# Altium schematic sheet 5.04.51805A02_STMSch.SchDoc(5.04.51805A02_STMSch)
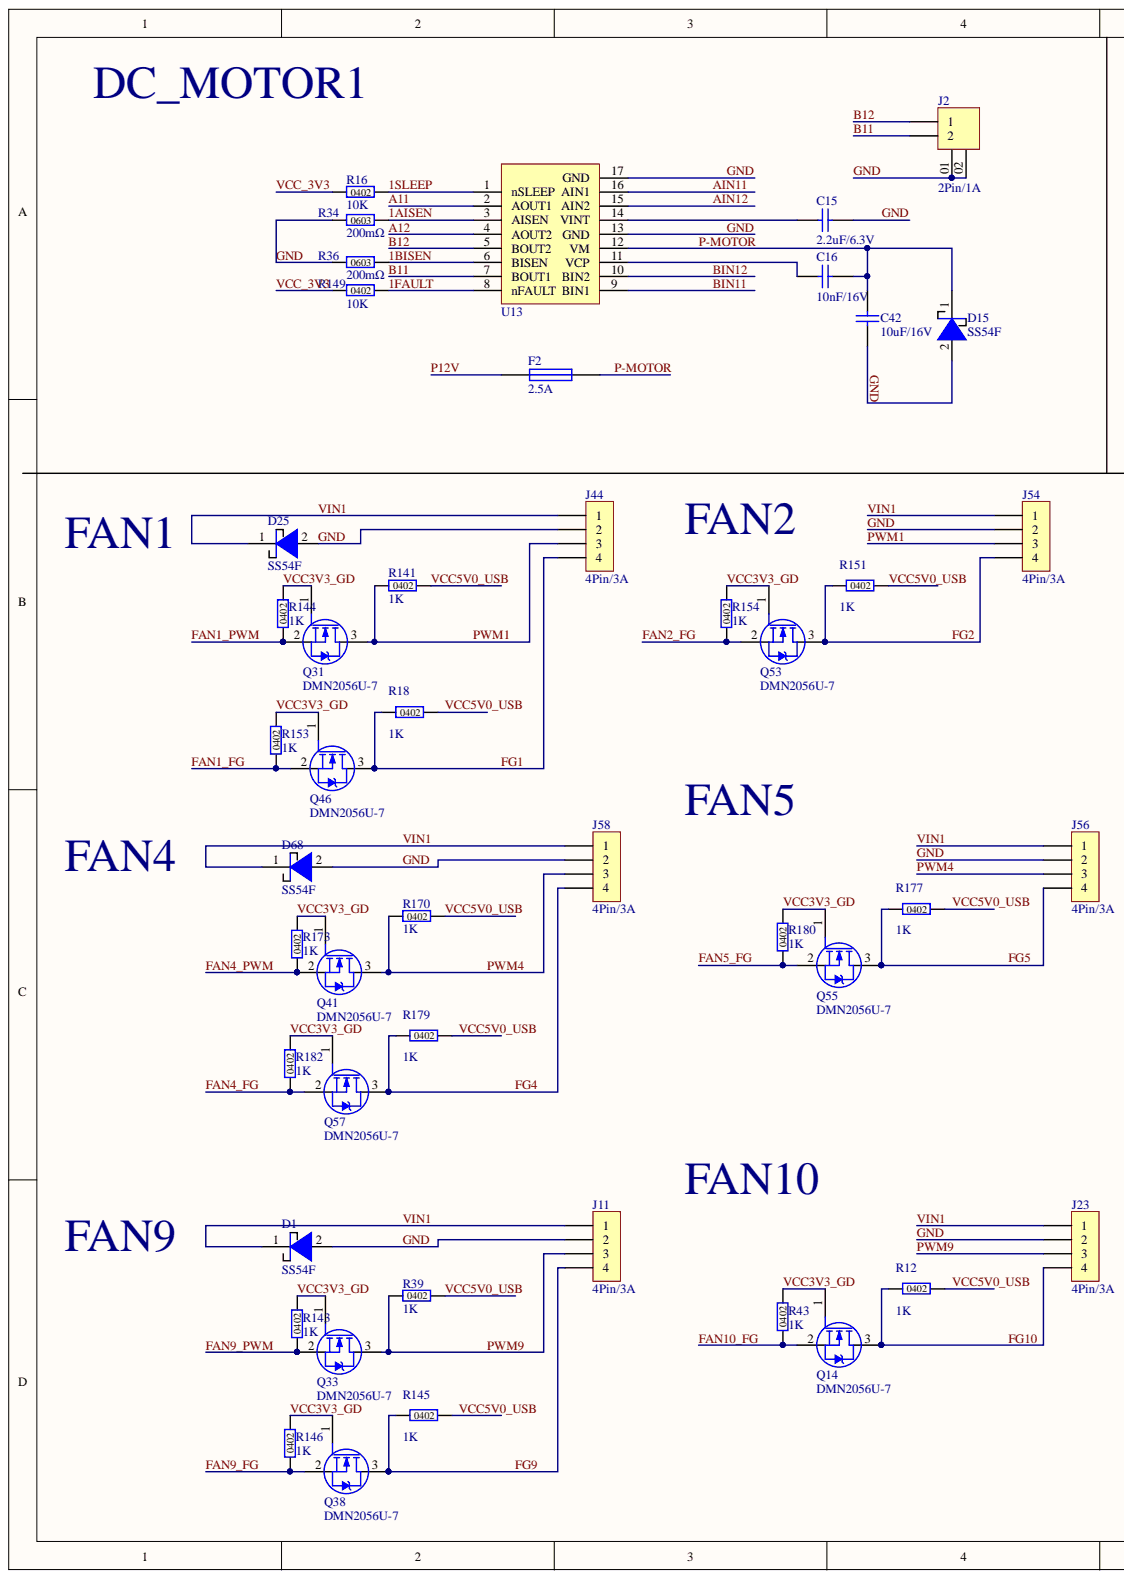
[diagram p0: 5.04.51805A02_STMSch.SchDoc, left]
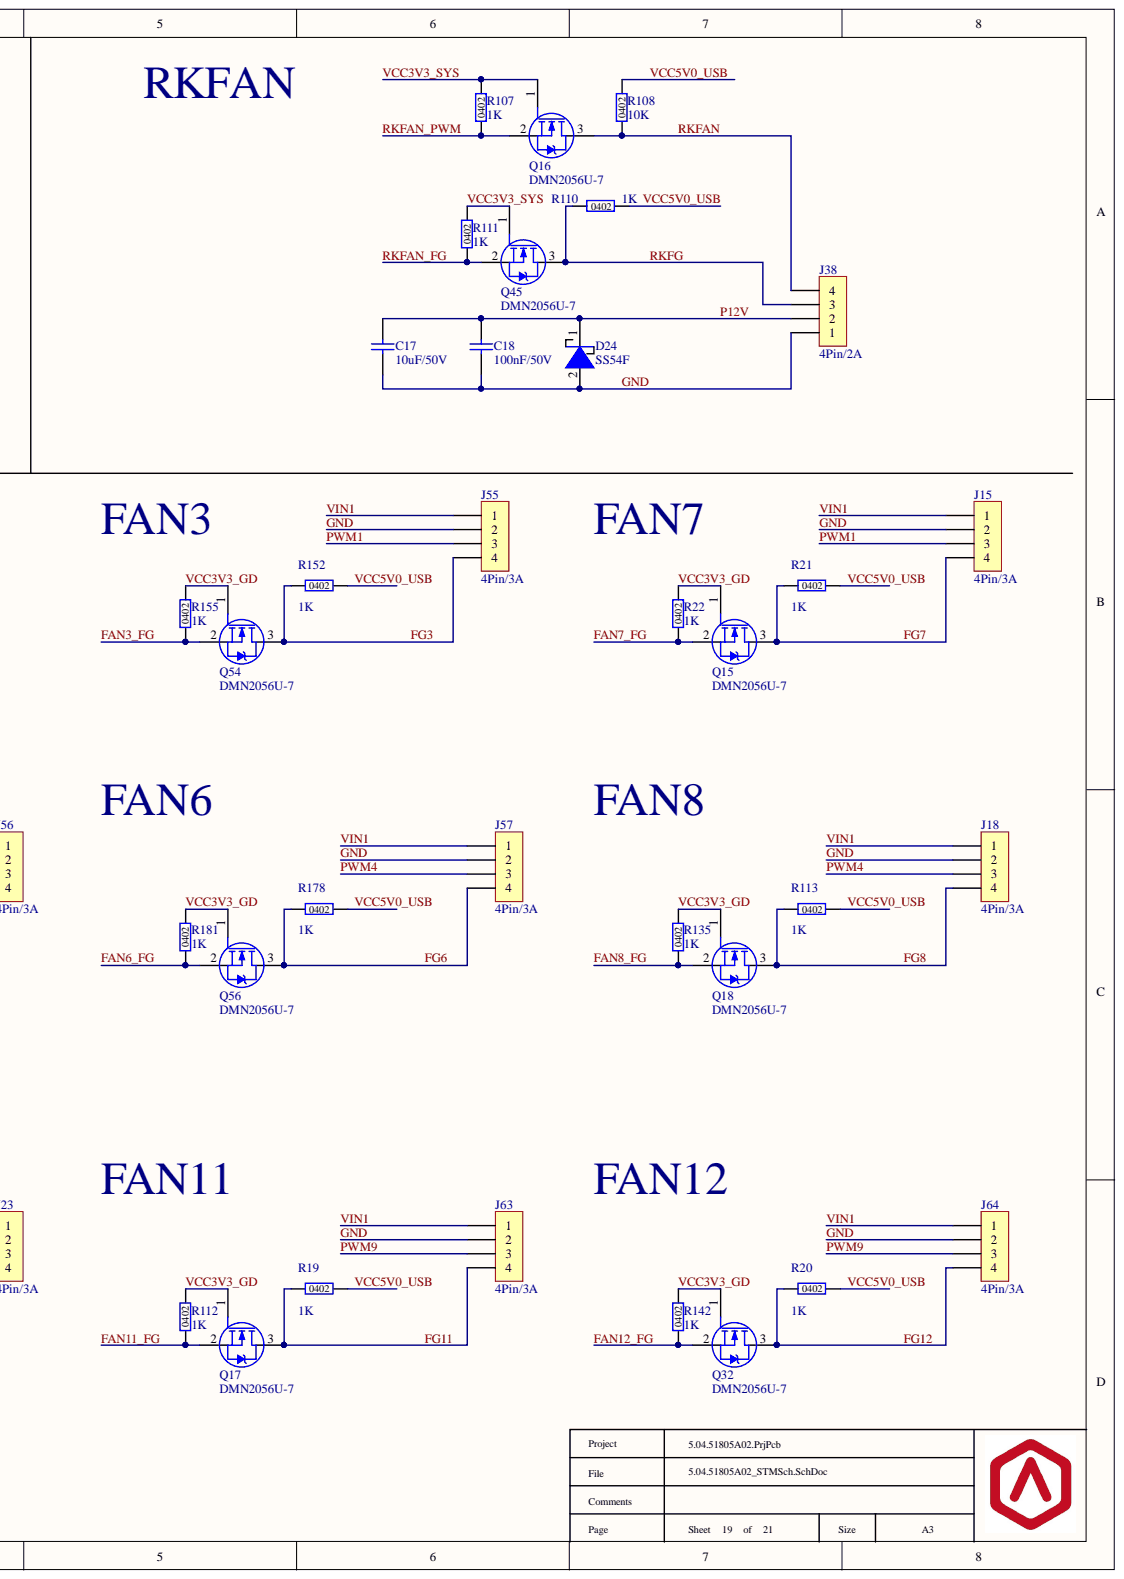
[diagram p1: 5.04.51805A02_STMSch.SchDoc, right]

## Parts
C15  2.2uF/6.3V  [C0402]
C16  10nF/16V  [C0402]
C17  10uF/50V  [C0805]
C18  100nF/50V  [C0402]
C42  10uF/16V  [C0603]
D1  SS54F  [SMAF_L3.5-W2.6-LS4.7-RD]
D15  SS54F  [SMAF_L3.5-W2.6-LS4.7-RD]
D24  SS54F  [SMAF_L3.5-W2.6-LS4.7-RD]
D25  SS54F  [SMAF_L3.5-W2.6-LS4.7-RD]
D68  SS54F  [SMAF_L3.5-W2.6-LS4.7-RD]
F2  2.5A  [F0603]
J2  2Pin/1A  [BM02B-GHS-TBT(LF)(SN)]
J11  4Pin/3A  [B04B-PASK-1(LF)(SN)]
J15  4Pin/3A  [B04B-PASK-1(LF)(SN)]
J18  4Pin/3A  [B04B-PASK-1(LF)(SN)]
J23  4Pin/3A  [B04B-PASK-1(LF)(SN)]
J38  4Pin/2A  [B4B-PH-K-S(LF)(SN)]
J44  4Pin/3A  [B04B-PASK-1(LF)(SN)]
J54  4Pin/3A  [B04B-PASK-1(LF)(SN)]
J55  4Pin/3A  [B04B-PASK-1(LF)(SN)]
J56  4Pin/3A  [B04B-PASK-1(LF)(SN)]
J57  4Pin/3A  [B04B-PASK-1(LF)(SN)]
J58  4Pin/3A  [B04B-PASK-1(LF)(SN)]
J63  4Pin/3A  [B04B-PASK-1(LF)(SN)]
J64  4Pin/3A  [B04B-PASK-1(LF)(SN)]
Q14  DMN2056U-7  [SOT-23-3]
Q15  DMN2056U-7  [SOT-23-3]
Q16  DMN2056U-7  [SOT-23-3]
Q17  DMN2056U-7  [SOT-23-3]
Q18  DMN2056U-7  [SOT-23-3]
Q31  DMN2056U-7  [SOT-23-3]
Q32  DMN2056U-7  [SOT-23-3]
Q33  DMN2056U-7  [SOT-23-3]
Q38  DMN2056U-7  [SOT-23-3]
Q41  DMN2056U-7  [SOT-23-3]
Q45  DMN2056U-7  [SOT-23-3]
Q46  DMN2056U-7  [SOT-23-3]
Q53  DMN2056U-7  [SOT-23-3]
Q54  DMN2056U-7  [SOT-23-3]
Q55  DMN2056U-7  [SOT-23-3]
Q56  DMN2056U-7  [SOT-23-3]
Q57  DMN2056U-7  [SOT-23-3]
R12  1K  [R0402]
R16  10K  [R0402]
R18  1K  [R0402]
R19  1K  [R0402]
R20  1K  [R0402]
R21  1K  [R0402]
R22  1K  [R0402]
R34  200mΩ  [R0603]
R36  200mΩ  [R0603]
R39  1K  [R0402]
R43  1K  [R0402]
R107  1K  [R0402]
R108  10K  [R0402]
R110  1K  [R0402]
R111  1K  [R0402]
R112  1K  [R0402]
R113  1K  [R0402]
R135  1K  [R0402]
R141  1K  [R0402]
R142  1K  [R0402]
R143  1K  [R0402]
R144  1K  [R0402]
R145  1K  [R0402]
R146  1K  [R0402]
R149  10K  [R0402]
R151  1K  [R0402]
R152  1K  [R0402]
R153  1K  [R0402]
R154  1K  [R0402]
R155  1K  [R0402]
R170  1K  [R0402]
R173  1K  [R0402]
R177  1K  [R0402]
R178  1K  [R0402]
R179  1K  [R0402]
R180  1K  [R0402]
R181  1K  [R0402]
R182  1K  [R0402]
U13  HR8833MTE  [TSSOP-16_L5.0-W4.4-P0.65-LS6.4-BL-EP3.0]  (value not drawn)

## Nets
1AISEN: R34.2 U13.3(AISEN)
1BISEN: R36.2 U13.6(BISEN)
1FAULT: R149.2 U13.8(nFAULT)
1SLEEP: R16.2 U13.1(nSLEEP)
A11: U13.2(AOUT1)
A12: U13.4(AOUT2)
AIN11: U13.16(AIN1)
AIN12: U13.15(AIN2)
B11: J2.2 U13.7(BOUT1)
B12: J2.1 U13.5(BOUT2)
BIN11: U13.9(BIN1)
BIN12: U13.10(BIN2)
FAN1_FG: Q46.2 R153.1
FAN1_PWM: Q31.2 R144.1
FAN2_FG: Q53.2 R154.1
FAN3_FG: Q54.2 R155.1
FAN4_FG: Q57.2 R182.1
FAN4_PWM: Q41.2 R173.1
FAN5_FG: Q55.2 R180.1
FAN6_FG: Q56.2 R181.1
FAN7_FG: Q15.2 R22.1
FAN8_FG: Q18.2 R135.1
FAN9_FG: Q38.2 R146.1
FAN9_PWM: Q33.2 R143.1
FAN10_FG: Q14.2 R43.1
FAN11_FG: Q17.2 R112.1
FAN12_FG: Q32.2 R142.1
FG1: J44.4 Q46.3 R18.2
FG2: J54.4 Q53.3 R151.2
FG3: J55.4 Q54.3 R152.2
FG4: J58.4 Q57.3 R179.2
FG5: J56.4 Q55.3 R177.2
FG6: J57.4 Q56.3 R178.2
FG7: J15.4 Q15.3 R21.2
FG8: J18.4 Q18.3 R113.2
FG9: J11.4 Q38.3 R145.2
FG10: J23.4 Q14.3 R12.2
FG11: J63.4 Q17.3 R19.2
FG12: J64.4 Q32.3 R20.2
GND: C15.2 C17.1 C18.1 C42.1 D1.2 D15.2 D24.2 D25.2 D68.2 J2.01 J2.02 J11.2 J15.2 J18.2 J23.2 J38.1 J44.2 J54.2 J55.2 J56.2 J57.2 J58.2 J63.2 J64.2 R34.1 R36.1 U13.13(GND) U13.17(GND)
P12V: C17.2 C18.2 D24.1 F2.1 J38.2
P-MOTOR: C16.2 C42.2 D15.1 F2.2 U13.12(VM)
PWM1: J15.3 J44.3 J54.3 J55.3 Q31.3 R141.2
PWM4: J18.3 J56.3 J57.3 J58.3 Q41.3 R170.2
PWM9: J11.3 J23.3 J63.3 J64.3 Q33.3 R39.2
RKFAN: J38.4 Q16.3 R108.1
RKFAN_FG: Q45.2 R111.1
RKFAN_PWM: Q16.2 R107.1
RKFG: J38.3 Q45.3 R110.2
VCC3V3_GD: Q14.1 Q15.1 Q17.1 Q18.1 Q31.1 Q32.1 Q33.1 Q38.1 Q41.1 Q46.1 Q53.1 Q54.1 Q55.1 Q56.1 Q57.1 R22.2 R43.2 R112.2 R135.2 R142.2 R143.2 R144.2 R146.2 R153.2 R154.2 R155.2 R173.2 R180.2 R181.2 R182.2
VCC3V3_SYS: Q16.1 Q45.1 R107.2 R111.2
VCC5V0_USB: R12.1 R18.1 R19.1 R20.1 R21.1 R39.1 R108.2 R110.1 R113.1 R141.1 R145.1 R151.1 R152.1 R170.1 R177.1 R178.1 R179.1
VCC_3V3: R16.1 R149.1
VIN1: D1.1 D25.1 D68.1 J11.1 J15.1 J18.1 J23.1 J44.1 J54.1 J55.1 J56.1 J57.1 J58.1 J63.1 J64.1
NetC15_1: C15.1 U13.14(VINT)
NetC16_1: C16.1 U13.11(VCP)

## Library data of the parts
C15 = C0402_2.2uF_6.3V: Ceramic Capacitor, 2.2uF, ±10%, 6.3V, -55°C~85°C, C0402; Manufacturer1: Yageo; ManufacturerPartNumber1: CC0402KRX5R5BB225; Code: 5151C225; Component Kind: Standard; Library Name: Raise3D_Capacitor.SCHLIB
C16 = C0402_10nF_16V: Ceramic Capacitor, 10nF, ±10%, 16V, -55°C~125°C, C0402; Manufacturer1: Yageo; ManufacturerPartNumber1: CC0402KPX7R7BB103; Code: 5171C103; Component Kind: Standard; Library Name: Raise3D_Capacitor.SCHLIB
C17 = C0805_10uF_50V: Ceramic Capacitor, 10uF, ±10%, 50V, -55°C~85°C, C0805; Manufacturer1: Yageo; ManufacturerPartNumber1: CC0805KKX5R9BB106; Code: 5193C106; Component Kind: Standard; Library Name: Raise3D_Capacitor.IntLib
C18 = C0402_100nF_50V: Ceramic Capacitor, 100nF, ±10%, 50V, -55°C~125°C, C0402; Manufacturer1: Yageo; ManufacturerPartNumber1: CC0402KRX7R9BB104; Code: 5191C104; Component Kind: Standard; Manufacturer2: MuRata; ManufacturerPartNumber2: GRM155R71H104KE14D; Library Name: Raise3D_Capacitor.IntLib
C42 = C0603_10uF_16V: Ceramic Capacitor, 10uF, ±10%, 16V, -55°C~85°C, C0603; Manufacturer1: SAMSUNG; ManufacturerPartNumber1: CL10A106KO8NQNC; Code: 5172C106; Component Kind: Standard; Library Name: Raise3D_Capacitor.SCHLIB
D1 D15 D24 D25 D68 = SBD_SS54F: SBD,SS54F,40V,550mV,5A,SMB,-65°C~150°C; Manufacturer1: Diodes; Code: 530300XX; Component Kind: Standard; ManufacturerPartNumber1: SS54F; Library Name: Raise3D_Diode.IntLib
F2 = Fuse_2.5A: 保险丝,SF-0603S250M-2,32V,2.5A,35A,32mOhms,F0603,-55°C~125°C; Manufacturer1: Bourns; Code: 56010008; Component Kind: Standard; ManufacturerPartNumber1: SF-0603S250M-2; Library Name: Raise3D_Fuse.IntLib
J2 = JW_BM02B-GHS-TBT(LF)(SN): 线对板连接器,2PINS,Pitch1.25mm,SMT,OR,1A,米黄,-40°C~85°C; Manufacturer1: JST; Code: 55010001; Component Kind: Standard; Manufacturer2: 健坤; ManufacturerPartNumber1: BM02B-GHS-TBT(LF)(SN); ManufacturerPartNumber2: A1253AWV-02-F1CB1-P; Library Name: Raise3D_Connector.IntLib
J11 J15 J18 J23 J44 J54-J58 J63 J64 = JW_B04B-PASK-1(LF)(SN): 线对板连接器,4PINS,Pitch2mm,TH,OR,3A,白,-25°C~85°C; Manufacturer1: JST; Code: 55010010; Component Kind: Standard; Manufacturer2: 红星电业（HXH）; ManufacturerPartNumber1: B04B-PASK-1(LF)(SN); ManufacturerPartNumber2: HX20018-4A/BH; Library Name: Raise3D_Connector.IntLib
J38 = JW_B4B-PH-K-S(LF)(SN): 线对板连接器,4PINS,Pitch2mm,TH,OR,2A,白,-25°C~85°C; Manufacturer1: JST; Code: 55010011; Component Kind: Standard; Manufacturer2: 红星电业（HXH）; ManufacturerPartNumber1: B4B-PH-K-S(LF)(SN); ManufacturerPartNumber2: HX20007-4A; Library Name: Raise3D_Connector.IntLib
Q14-Q18 Q31-Q33 Q38 Q41 Q45 Q46 Q53-Q57 = NMOS_DMN2056U-7: N-MOSFET,DMN2056U-7,1Channel,Vds=20V,Id=4A,Vgs=+/-8V,TO-236-3,-55°C~150°C; Manufacturer1: DIODES; Code: 54010011; Component Kind: Standard; ManufacturerPartNumber1: DMN2056U-7
  Q14 Q15 Q17 Q18 Q32 Q38 Q45 Q46 Q53-Q57: Library Name: Raise3D_MOS&BJT.IntLib
  Q16 Q31 Q33 Q41: Library Name: Raise3D_MOS&BJT.SCHLIB
R12 R18-R22 R39 R43 R107 R110-R113 R135 R141-R146 R151-R155 R170 R173 R177-R182 = R0402_1K: Resistor 1Kohm,5%,1/16W,R0402; Manufacturer1: Yageo; ManufacturerPartNumber1: RC0402JR-071KL; Code: 5011001K; Component Kind: Standard; Library Name: Raise3D_Resistor.IntLib
R16 R108 R149 = R0402_10K: Resistor 10Kohm,1%,1/16W,R0402; Manufacturer1: Yageo; ManufacturerPartNumber1: RC0402FR-0710KL; Code: 5001010K; Component Kind: Standard; Manufacturer2: Samsung; ManufacturerPartNumber2: RC1005F103CS; Library Name: Raise3D_Resistor.IntLib
R34 R36 = R0603_200m: Resistor 200mohm,1%,125mW,-55~155℃,R0805; Manufacturer1: YAGEO; ManufacturerPartNumber1: RL0603FR-070R2L; Code: C723543; Component Kind: Standard; Manufacturer2: UNI-ROYAL; ManufacturerPartNumber2: 0603WAF200LR5E; Library Name: Raise3D_General.IntLib
U13 = HR8833MTE: 有刷直流电机驱动芯片,0~12.8V,1A,-40℃~85℃,ETSSOP-16; Manufacturer1: HEROIC; Code: C128666; Component Kind: Standard; ManufacturerPartNumber1: HR8833MTE; Library Name: Raise3D_General.IntLib
